# Altium schematic sheet MCU.SchDoc
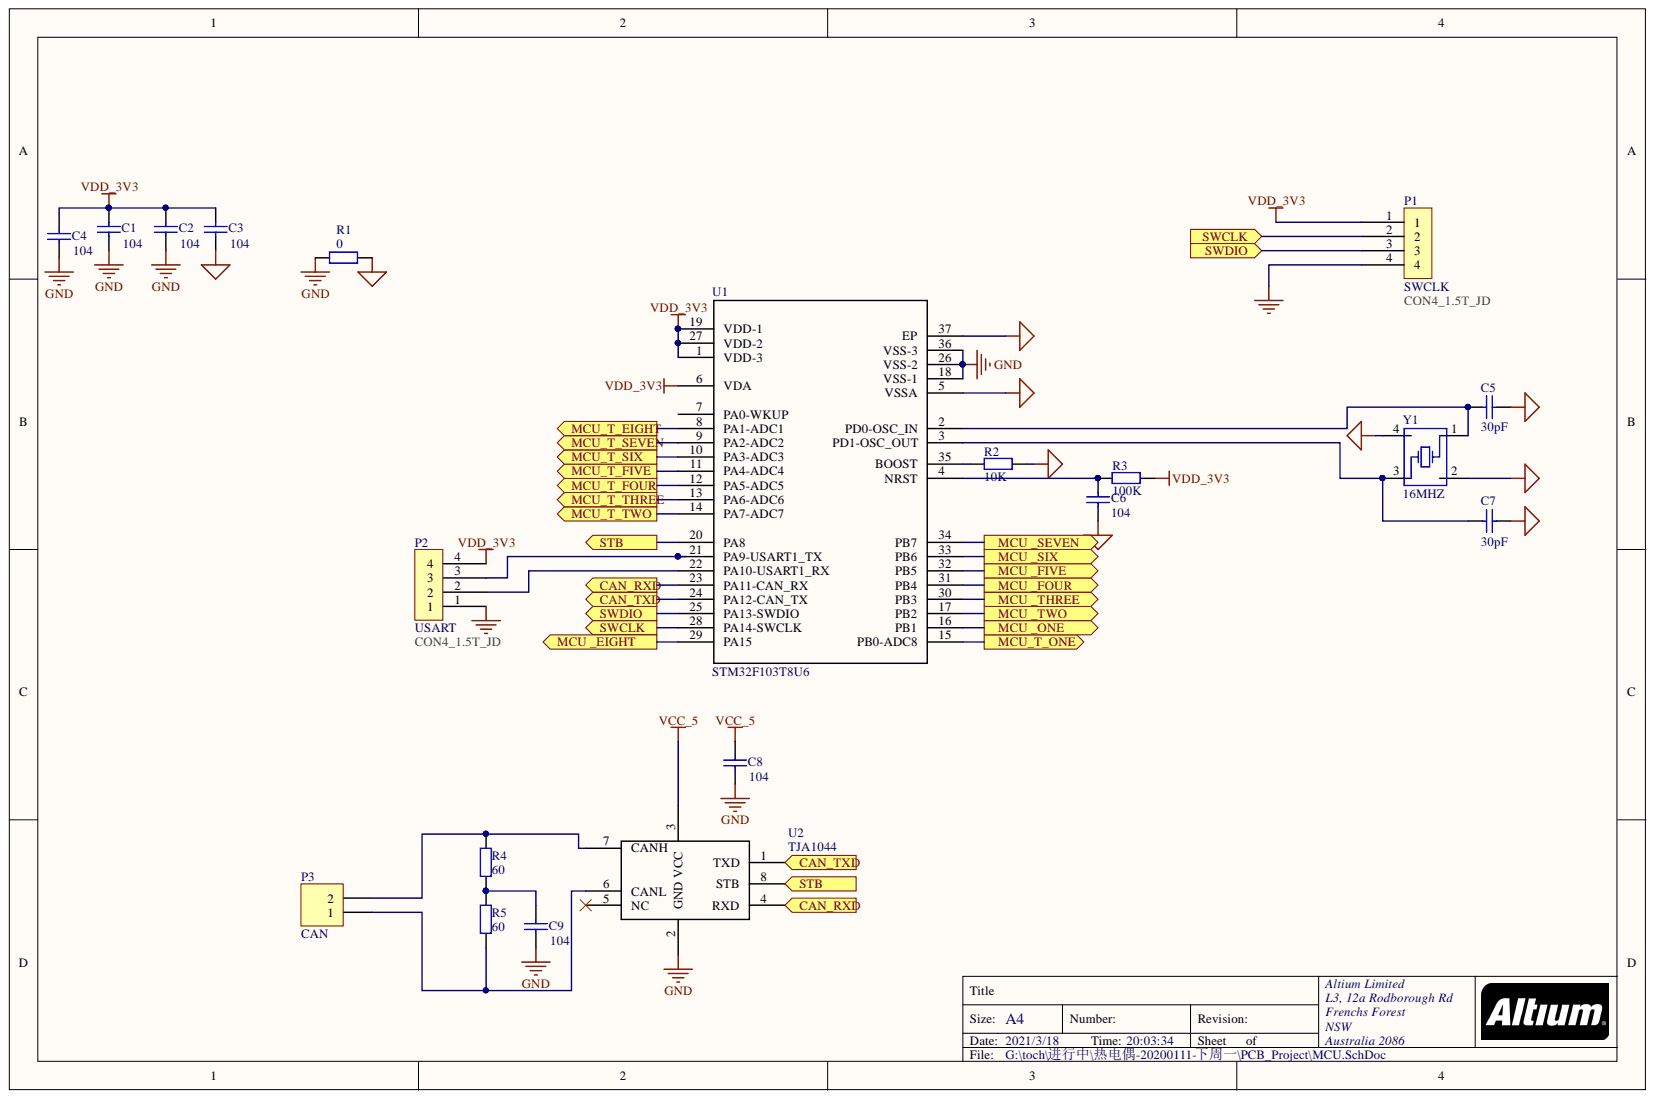
[diagram p0: MCU.SchDoc]

## Parts
C1  104  [0603_C]
C2  104  [0603_C]
C3  104  [0603_C]
C4  104  [0603_C]
C5  30pF  [0603_C]
C6  104  [0603_C]
C7  30pF  [0603_C]
C8  104  [0603_C]
C9  104  [0603_C]
P1  SWCLK  [CON1.5_4Opin]
P2  USART  [CON1.5_4Opin]
P3  CAN  [CON1.5_2pin]
R1  0  [0603_R]
R2  10K  [0603_R]
R3  100K  [0603_R]
R4  60  [0603_R]
R5  60  [0603_R]
U1  STM32F103T8U6  [STM32F103T8U6]
U2  TJA1044  [SOP-8_150mil]
Y1  16MHZ  [SMD-3225_4P]

## Ports
CAN_RXD, CAN_TXD, MCU _EIGHT, MCU _FIVE, MCU _FOUR, MCU _ONE, MCU _SEVEN, MCU _SIX, MCU _THREE, MCU _TWO, MCU_T_EIGHT, MCU_T_FIVE, MCU_T_FOUR, MCU_T_ONE, MCU_T_SEVEN, MCU_T_SIX, MCU_T_THREE, MCU_T_TWO, STB, SWCLK, SWDIO

## Nets
GND: C1.2 C2.2 C4.2 C8.2 C9.2 P1.4 P2.1 R1.1 U1.18(VSS-1) U1.26(VSS-2) U1.36(VSS-3) U2.2(GND)
SGND: C3.2 C5.2 C6.1 C7.2 R1.2 R2.1 U1.5(VSSA) U1.37(EP) Y1.2 Y1.4
VCC_5: C8.1 U2.3(VCC)
VDD_3V3: C1.1 C2.1 C3.1 C4.1 P1.1 P2.4 R3.2 U1.1(VDD-3) U1.6(VDA) U1.19(VDD-1) U1.27(VDD-2)
CAN_RXD: U1.23(PA11-CAN_RX) U2.4(RXD) ; port CAN_RXD
CAN_TXD: U1.24(PA12-CAN_TX) U2.1(TXD) ; port CAN_TXD
MCU _EIGHT: U1.29(PA15) ; port MCU _EIGHT
MCU _FIVE: U1.32(PB5) ; port MCU _FIVE
MCU _FOUR: U1.31(PB4) ; port MCU _FOUR
MCU _ONE: U1.16(PB1) ; port MCU _ONE
MCU _SEVEN: U1.34(PB7) ; port MCU _SEVEN
MCU _SIX: U1.33(PB6) ; port MCU _SIX
MCU _THREE: U1.30(PB3) ; port MCU _THREE
MCU _TWO: U1.17(PB2) ; port MCU _TWO
MCU_T_EIGHT: U1.8(PA1-ADC1) ; port MCU_T_EIGHT
MCU_T_FIVE: U1.11(PA4-ADC4) ; port MCU_T_FIVE
MCU_T_FOUR: U1.12(PA5-ADC5) ; port MCU_T_FOUR
MCU_T_ONE: U1.15(PB0-ADC8) ; port MCU_T_ONE
MCU_T_SEVEN: U1.9(PA2-ADC2) ; port MCU_T_SEVEN
MCU_T_SIX: U1.10(PA3-ADC3) ; port MCU_T_SIX
MCU_T_THREE: U1.13(PA6-ADC6) ; port MCU_T_THREE
MCU_T_TWO: U1.14(PA7-ADC7) ; port MCU_T_TWO
STB: U1.20(PA8) U2.8(STB) ; port STB
SWCLK: P1.2 U1.28(PA14-SWCLK) ; port SWCLK
SWDIO: P1.3 U1.25(PA13-SWDIO) ; port SWDIO
NetC5_1: C5.1 U1.2(PD0-OSC_IN) Y1.1
NetC6_2: C6.2 R3.1 U1.4(NRST)
NetC7_1: C7.1 U1.3(PD1-OSC_OUT) Y1.3
NetC9_1: C9.1 R4.1 R5.2
NetP2_2: P2.2 U1.22(PA10-USART1_RX)
NetP2_3: P2.3 U1.21(PA9-USART1_TX)
NetP3_1: P3.1 R5.1 U2.6(CANL)
NetP3_2: P3.2 R4.2 U2.7(CANH)
NetR2_2: R2.2 U1.35(BOOST)

Not connected: U1 7(PA0-WKUP); U2 5(NC)

## Library data of the parts
C1-C4 C6 C8 C9 = 0603 1uF (105) 10% 50V: 贴片电容; Component Kind: Standard; ComponentLink1Description: 供应商链接; ComponentLink1URL: http://www.szlcsc.com/product/details_16531.html; Library Name: Miscellaneous Devices LC.IntLib; Package: 0603; Supplier: LC; SuppliersPartNumber: C15849
C5 C7 = 0603 12pF (120) 5% 50V: 贴片电容; Component Kind: Standard; ComponentLink1Description: 供应商链接; ComponentLink1URL: http://www.szlcsc.com/product/details_39506.html; Library Name: Miscellaneous Devices LC.IntLib; Package: 0603; Supplier: LC; SuppliersPartNumber: C38523
P1 P2 = SIP_4: CON4_1.5T_JD; Component Kind: Standard; Parameter 1: CON4_1.5T_JD; Library Name: CONNECTER.SchLib
P3 = Header 2: Header, 2-Pin; Component Kind: Standard; LatestRevisionDate: 17-Jul-2002; LatestRevisionNote: Re-released for DXP Platform.; Library Name: Miscellaneous Connectors.IntLib; Signal Integrity: Connector; Publisher: Altium Limited
R1 R2 R4 R5 = 0603 10KΩ (1002) 1%: 贴片电阻; Component Kind: Standard; ComponentLink1Description: 供应商链接; ComponentLink1URL: http://www.szlcsc.com/product/details_26547.html; Library Name: Miscellaneous Devices LC.IntLib; Package: 0603; Supplier: LC; SuppliersPartNumber: C25804
R3 = 0603 100KΩ (1003) 1%: 贴片电阻; Component Kind: Standard; ComponentLink1Description: 供应商链接; ComponentLink1URL: http://www.szlcsc.com/product/details_26546.html; Library Name: Miscellaneous Devices LC.IntLib; Package: 0603; Supplier: LC; SuppliersPartNumber: C25803
U2 = TAJ1044: Component Kind: Standard; Library Name: Schlib1.SchLib
Y1 = SMD-3225_4P  16MHZ 9PF 10PPM: 贴片晶振; Component Kind: Standard; ComponentLink1Description: 供应商链接; ComponentLink1URL: http://www.szlcsc.com/product/details_14393.html; Library Name: Miscellaneous Devices LC.IntLib; Package: SMD-3225_4P; Supplier: LC; SuppliersPartNumber: C13738
U1 = STM32F103T8U6: Component Kind: Standard; Library Name: Schlib1.SchLib
